# Altium schematic sheet GD32F450IIH6.SchDoc(GD32F450IIH6)
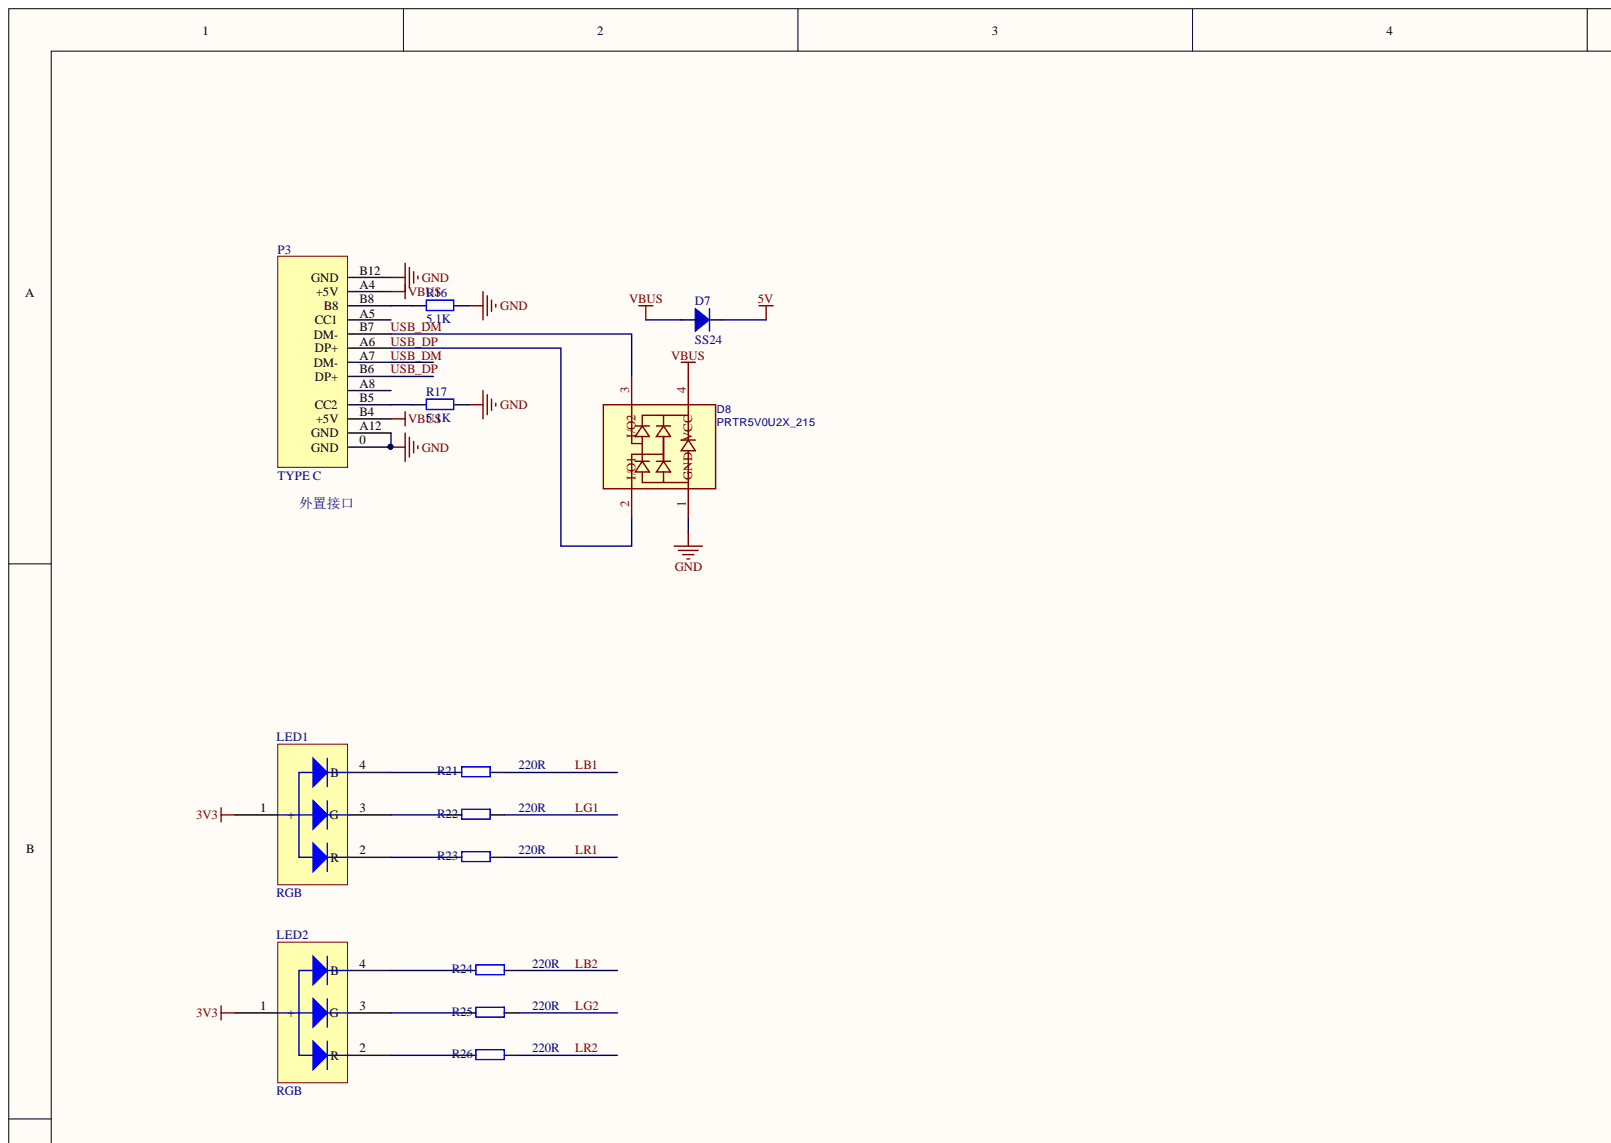
[diagram p0: GD32F450IIH6.SchDoc, top left]
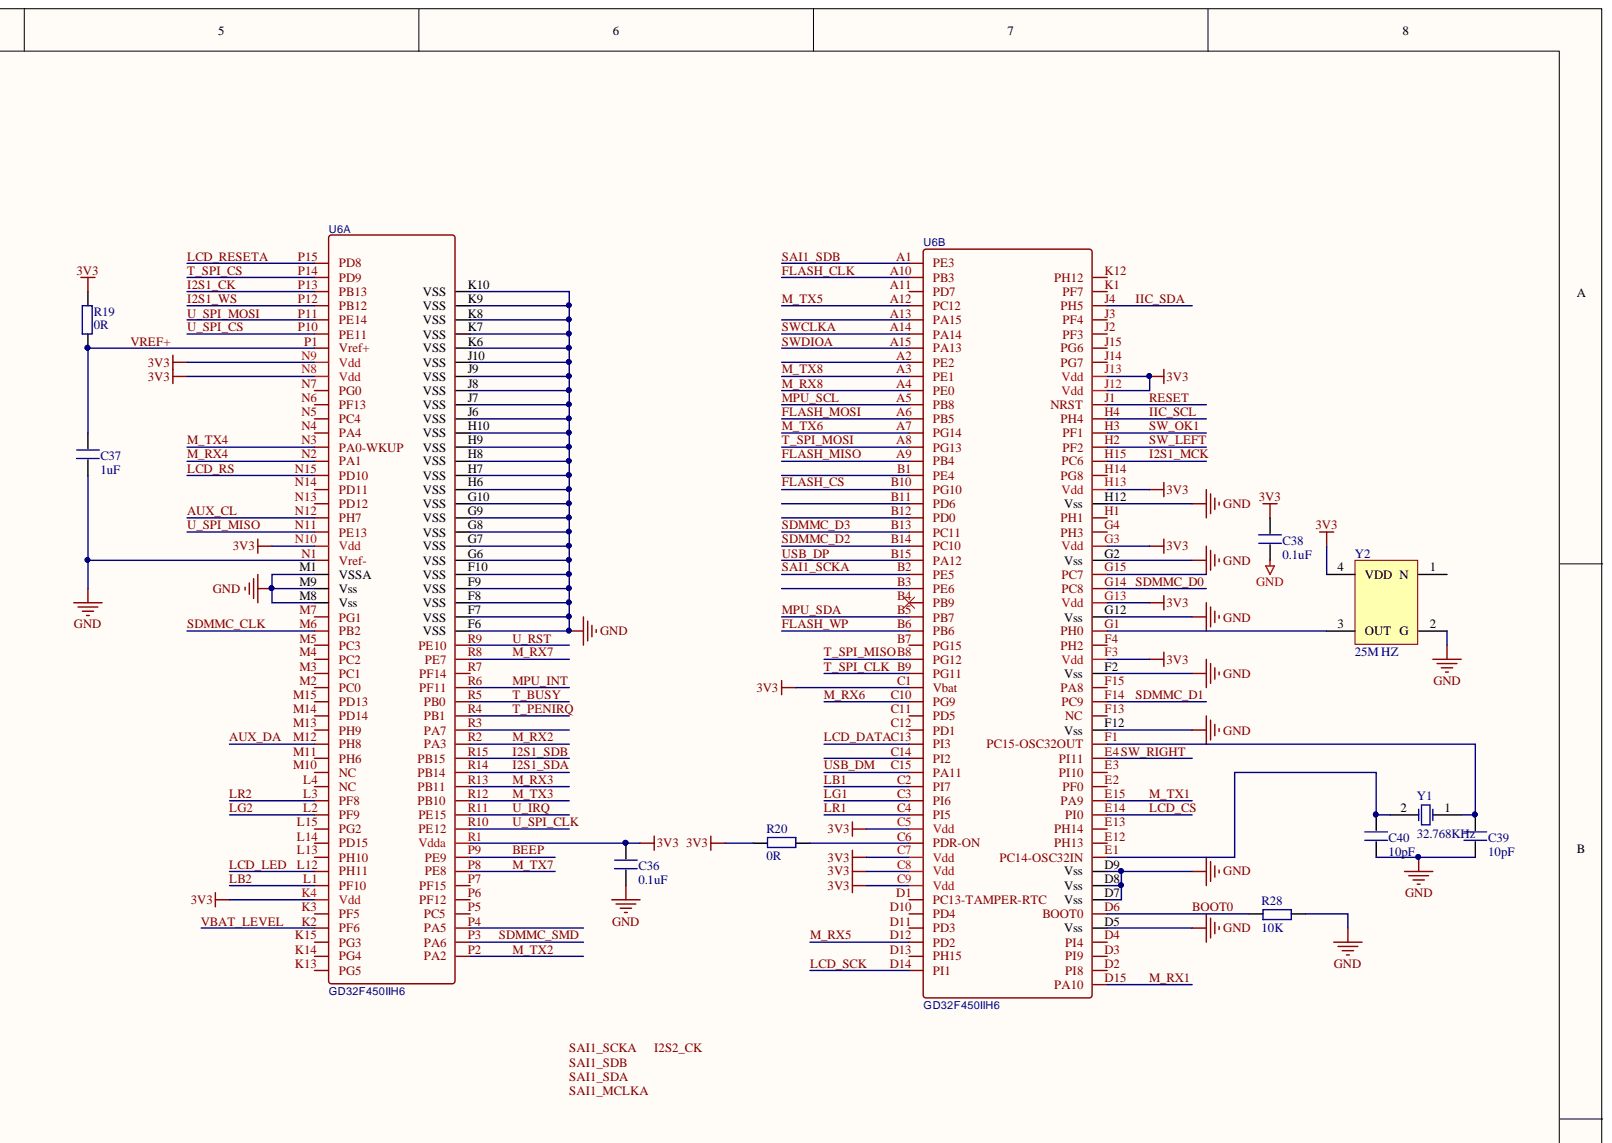
[diagram p1: GD32F450IIH6.SchDoc, top right]
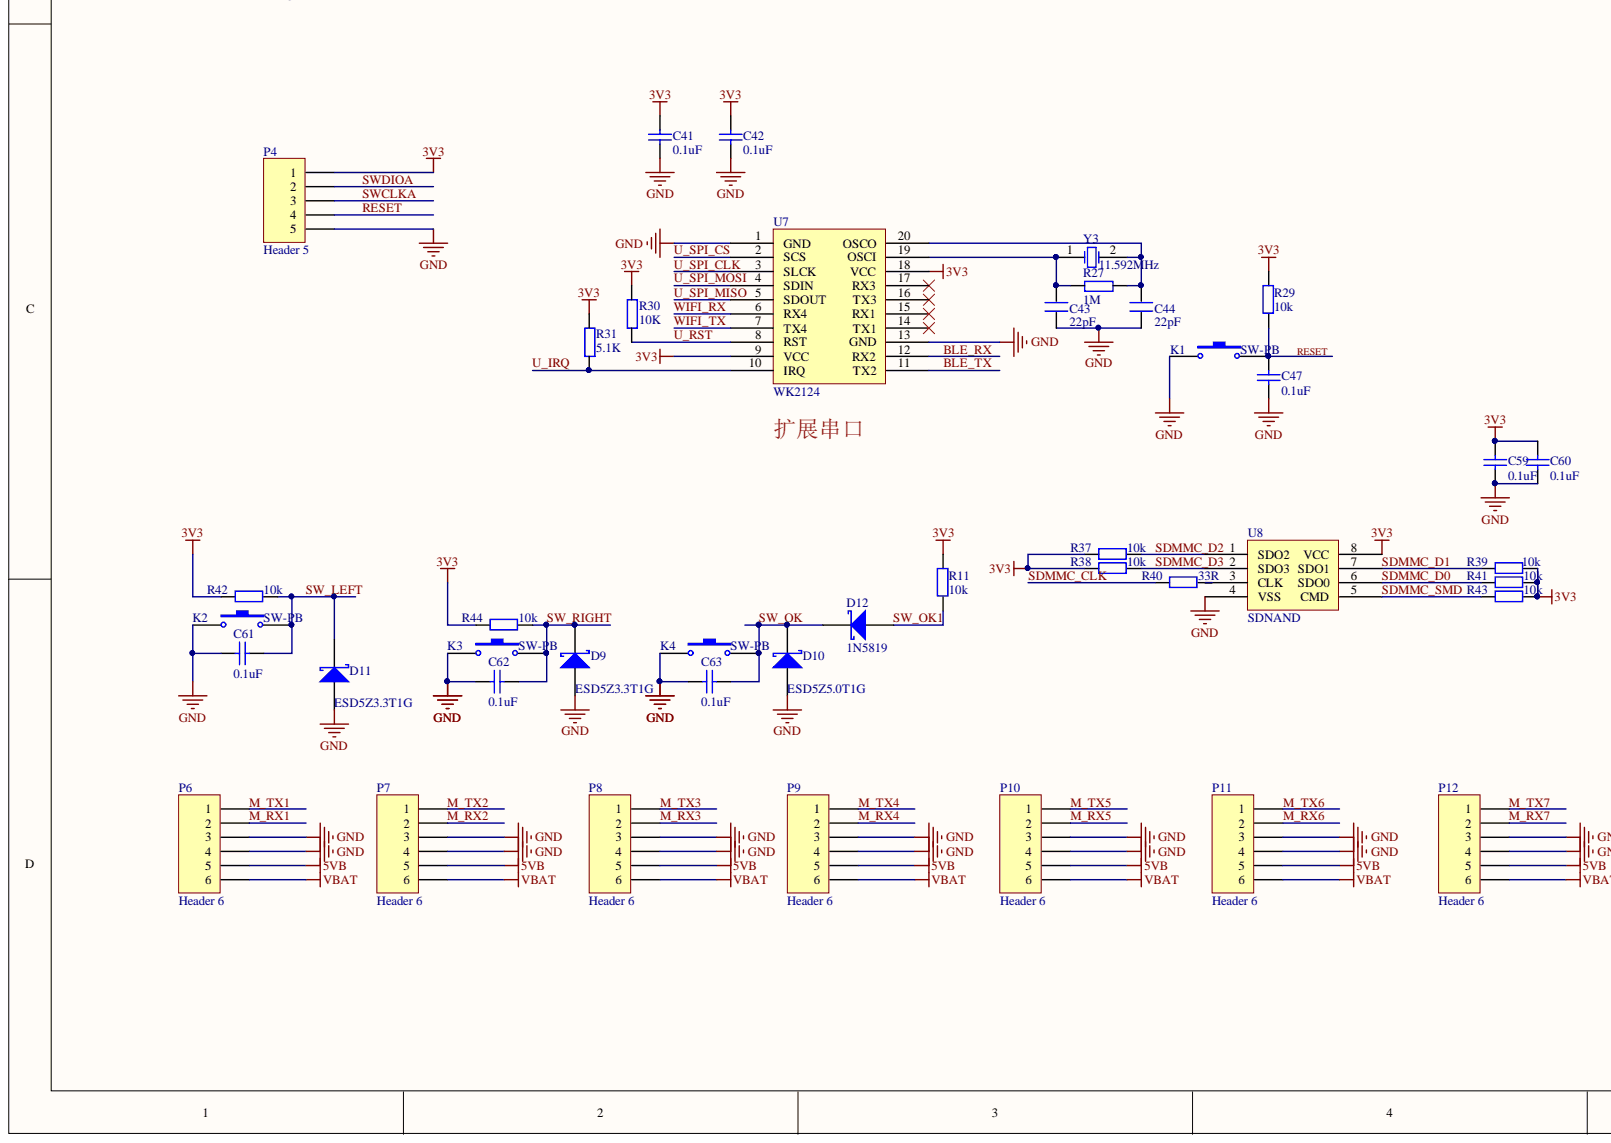
[diagram p2: GD32F450IIH6.SchDoc, bottom left]
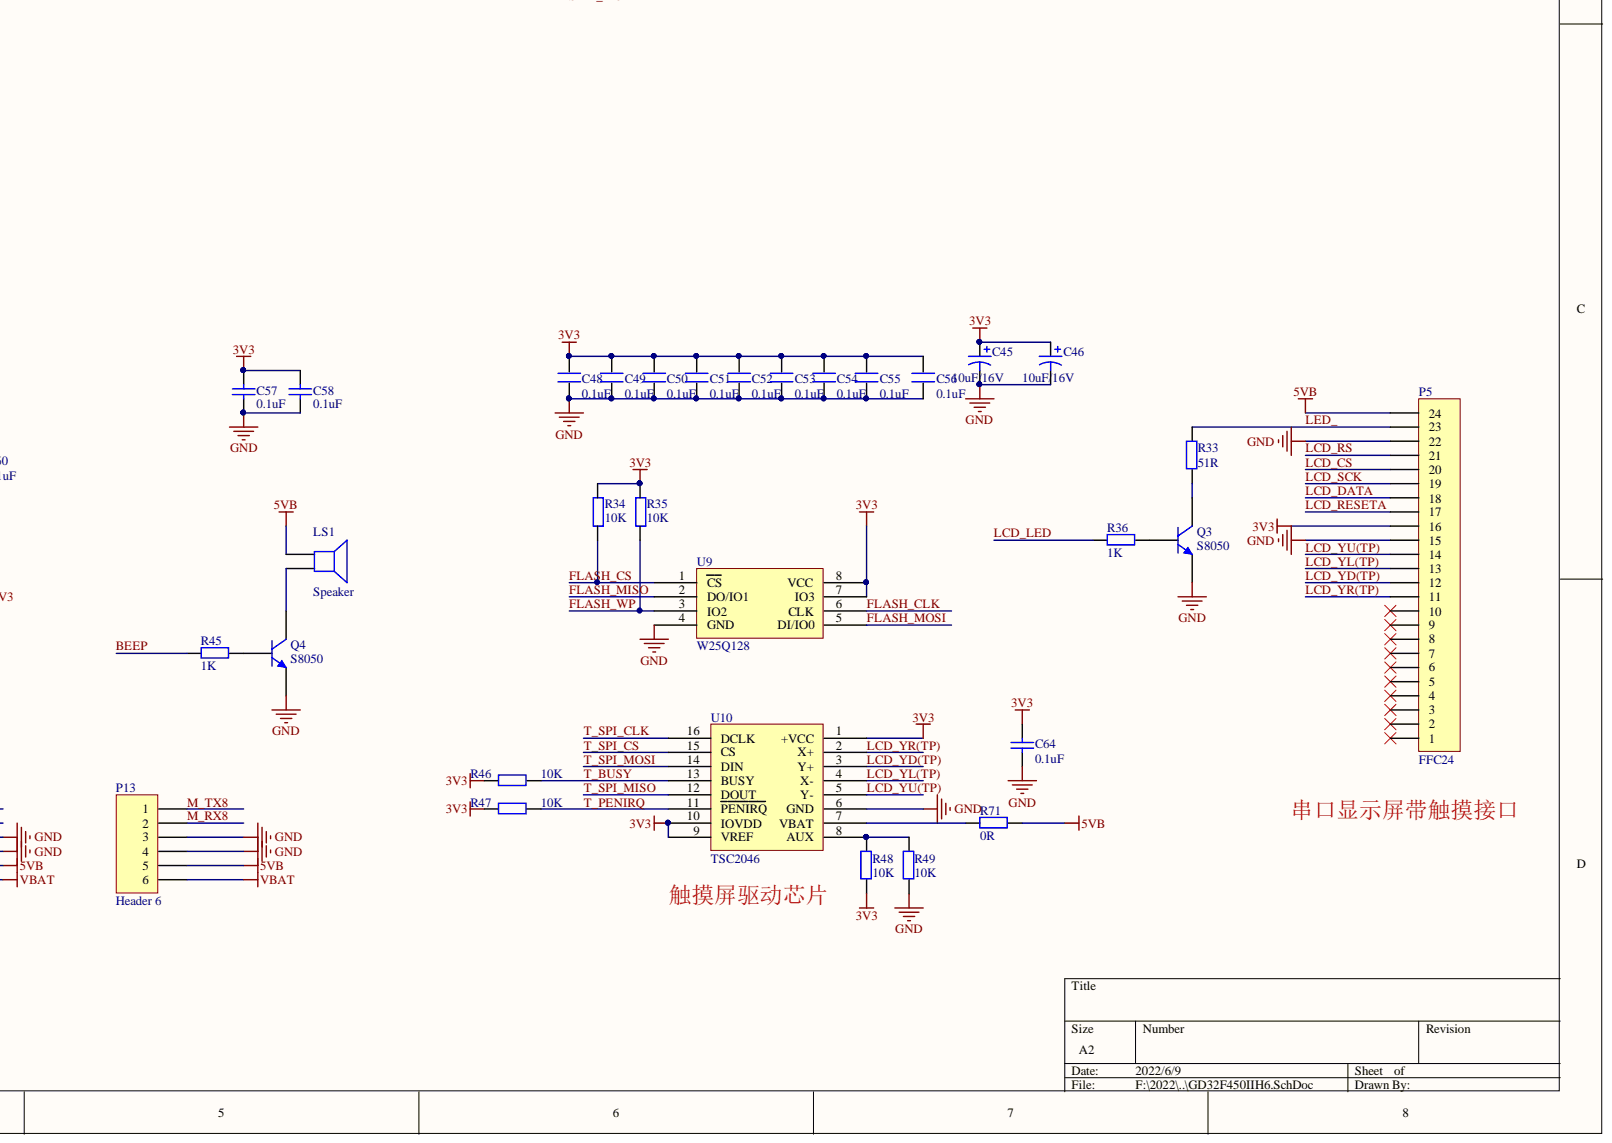
[diagram p3: GD32F450IIH6.SchDoc, bottom right]

## Parts
C36  0.1uF  [C0603]
C37  1uF  [C0603]
C38  0.1uF  [C0603]
C39  10pF  [C0603]
C40  10pF  [C0603]
C41  0.1uF  [C0603]
C42  0.1uF  [C0603]
C43  22pF  [C0603]
C44  22pF  [C0603]
C45  10uF/16V  [1206C+]
C46  10uF/16V  [1206C+]
C47  0.1uF  [C0603]
C48  0.1uF  [C0603]
C49  0.1uF  [C0603]
C50  0.1uF  [C0603]
C51  0.1uF  [C0603]
C52  0.1uF  [C0603]
C53  0.1uF  [C0603]
C54  0.1uF  [C0603]
C55  0.1uF  [C0603]
C56  0.1uF  [C0603]
C57  0.1uF  [C0603]
C58  0.1uF  [C0603]
C59  0.1uF  [C0603]
C60  0.1uF  [C0603]
C61  0.1uF  [C0603]
C62  0.1uF  [C0603]
C63  0.1uF  [C0603]
C64  0.1uF  [C0603]
D7  SS24  [SMA/DO-214AC]
D8  PRTR5V0U2X_215  [PRTR5V0U2X]
D9  ESD5Z3.3T1G  [SOD523]
D10  ESD5Z5.0T1G  [SOD523]
D11  ESD5Z3.3T1G  [SOD523]
D12  1N5819  [SOD323]
K1  SW-PB  [SW_4*4*1.5]
K2  SW-PB  [SW_4*4*1.5]
K3  SW-PB  [SW_4*4*1.5]
K4  SW-PB  [SW_4*4*1.5]
LED1  RGB  [LED_0603_RGB_Y]
LED2  RGB  [LED_0603_RGB_Y]
LS1  Speaker  [BUZ_9*5.5_5]
P3  TYPE C  [USB-TPC-WS]
P4  Header 5  [HDR1X5]
P5  FFC24  [FFC_0.5_24]
P6  Header 6  [WSK-CNTB]
P7  Header 6  [WSK-CNTB]
P8  Header 6  [WSK-CNTB]
P9  Header 6  [WSK-CNTB]
P10  Header 6  [WSK-CNTB]
P11  Header 6  [WSK-CNTB]
P12  Header 6  [WSK-CNTB]
P13  Header 6  [WSK-CNTB]
Q3  S8050  [SOT23]
Q4  S8050  [SOT23]
R11  10k  [R0603]
R16  5.1K  [R0603]
R17  5.1K  [R0603]
R19  0R  [R0603]
R20  0R  [R0603]
R21  220R  [R0603]
R22  220R  [R0603]
R23  220R  [R0603]
R24  220R  [R0603]
R25  220R  [R0603]
R26  220R  [R0603]
R27  1M  [R0603]
R28  10K  [R0603]
R29  10k  [R0603]
R30  10K  [R0603]
R31  5.1K  [R0603]
R33  51R  [R0603]
R34  10K  [R0603]
R35  10K  [R0603]
R36  1K  [R0603]
R37  10k  [R0603]
R38  10k  [R0603]
R39  10k  [R0603]
R40  33R  [R0603]
R41  10k  [R0603]
R42  10k  [R0603]
R43  10k  [R0603]
R44  10k  [R0603]
R45  1K  [R0603]
R46  10K  [R0603]
R47  10K  [R0603]
R48  10K  [R0603]
R49  10K  [R0603]
R71  0R  [R0603]
U6  GD32F450IIH6  [UFBGA-201_L10.0-W10.0-P0.65-TL_STM32H743IIK6]  (parts A,B)
U7  WK2124  [SSOP-20_L7.5-W5.2]
U8  SDNAND  [LGA-8_L6.0-W8.0]
U9  W25Q128  [SOP8_W]
U10  TSC2046  [SSOP16]
Y1  32.768KHz  [X_2012]
Y2  25M HZ  [X_SMD_5*3.2]
Y3  11.592MHz  [X_5032]

## Nets
3V3: C36.2 C38.2 C41.2 C42.2 C45.1 C46.1 C48.2 C49.2 C50.2 C51.2 C52.2 C53.2 C54.2 C55.2 C56.2 C57.2 C58.2 C59.2 C60.2 C64.2 LED1.1(+) LED2.1(+) P4.1 P5.16 R11.2 R19.2 R20.2 R29.1 R30.2 R31.2 R34.2 R35.2 R37.1 R38.1 R39.2 R41.2 R42.2 R43.2 R44.2 R46.2 R47.2 R48.2 U6.C1(Vbat) U6.C5(Vdd) U6.C7(Vdd) U6.C8(Vdd) U6.C9(Vdd) U6.F3(Vdd) U6.G3(Vdd) U6.G13(Vdd) U6.H13(Vdd) U6.J12(Vdd) U6.J13(Vdd) U6.K4(Vdd) U6.N8(Vdd) U6.N9(Vdd) U6.N10(Vdd) U6.R1(Vdda) U7.9(VCC) U7.18(VCC) U8.8(VCC) U9.7(IO3) U9.8(VCC) U10.1(+VCC) U10.9(VREF) U10.10(IOVDD) Y2.4(VDD)
5V: D7.2
5VB: LS1.1 P5.24 P6.5 P7.5 P8.5 P9.5 P10.5 P11.5 P12.5 P13.5 R71.2
GND: C36.1 C37.1 C38.1 C39.1 C40.1 C41.1 C42.1 C43.1 C44.1 C45.2 C46.2 C47.1 C48.1 C49.1 C50.1 C51.1 C52.1 C53.1 C54.1 C55.1 C56.1 C57.1 C58.1 C59.1 C60.1 C61.1 C62.1 C63.1 C64.1 D8.1(GND) D9.1 D10.1 D11.1 K1.1 K2.1 K3.1 K4.1 P3.0(GND) P3.A12(GND) P3.B12(GND) P4.5 P5.15 P5.22 P6.3 P6.4 P7.3 P7.4 P8.3 P8.4 P9.3 P9.4 P10.3 P10.4 P11.3 P11.4 P12.3 P12.4 P13.3 P13.4 Q3.2 Q4.2 R16.1 R17.1 R28.2 R49.2 U6.D5(Vss) U6.D7(Vss) U6.D8(Vss) U6.D9(Vss) U6.F2(Vss) U6.F6(VSS) U6.F7(VSS) U6.F8(VSS) U6.F9(VSS) U6.F10(VSS) U6.F12(Vss) U6.G2(Vss) U6.G6(VSS) U6.G7(VSS) U6.G8(VSS) U6.G9(VSS) U6.G10(VSS) U6.G12(Vss) U6.H6(VSS) U6.H7(VSS) U6.H8(VSS) U6.H9(VSS) U6.H10(VSS) U6.H12(Vss) U6.J6(VSS) U6.J7(VSS) U6.J8(VSS) U6.J9(VSS) U6.J10(VSS) U6.K6(VSS) U6.K7(VSS) U6.K8(VSS) U6.K9(VSS) U6.K10(VSS) U6.M1(VSSA) U6.M8(Vss) U6.M9(Vss) U6.N1(Vref-) U7.1(GND) U7.13(GND) U8.4(VSS) U9.4(GND) U10.6(GND) Y2.2(G)
VBAT: P6.6 P7.6 P8.6 P9.6 P10.6 P11.6 P12.6 P13.6
VBUS: D7.1 D8.4(VCC) P3.A4(+5V) P3.B4(+5V)
AUX_CL: U6.N12(PH7)
AUX_DA: U6.M12(PH8)
BEEP: R45.1 U6.P9(PE9)
BLE_RX: U7.12(RX2)
BLE_TX: U7.11(TX2)
BOOT0: R28.1 U6.D6(BOOT0)
FLASH_CLK: U6.A10(PB3) U9.6(CLK)
FLASH_CS: R34.1 U6.B10(PG10) U9.1(CS)
FLASH_MISO: U6.A9(PB4) U9.2(DO/IO1)
FLASH_MOSI: U6.A6(PB5) U9.5(DI/IO0)
FLASH_WP: R35.1 U6.B6(PB6) U9.3(IO2)
I2S1_CK: U6.P13(PB13)
I2S1_MCK: U6.H15(PC6)
I2S1_SDA: U6.R14(PB14)
I2S1_SDB: U6.R15(PB15)
I2S1_WS: U6.P12(PB12)
IIC_SCL: U6.H4(PH4)
IIC_SDA: U6.J4(PH5)
LB1: R21.1 U6.C2(PI7)
LB2: R24.1 U6.L1(PF10)
LCD_CS: P5.20 U6.E14(PI0)
LCD_DATA: P5.18 U6.C13(PI3)
LCD_LED: R36.1 U6.L12(PH11)
LCD_RESETA: P5.17 U6.P15(PD8)
LCD_RS: P5.21 U6.N15(PD10)
LCD_SCK: P5.19 U6.D14(PI1)
LCD_YD(TP): P5.12 U10.3(Y+)
LCD_YL(TP): P5.13 U10.4(X-)
LCD_YR(TP): P5.11 U10.2(X+)
LCD_YU(TP): P5.14 U10.5(Y-)
LED_: P5.23 R33.1
LG1: R22.1 U6.C3(PI6)
LG2: R25.1 U6.L2(PF9)
LR1: R23.1 U6.C4(PI5)
LR2: R26.1 U6.L3(PF8)
M_RX1: P6.2 U6.D15(PA10)
M_RX2: P7.2 U6.R2(PA3)
M_RX3: P8.2 U6.R13(PB11)
M_RX4: P9.2 U6.N2(PA1)
M_RX5: P10.2 U6.D12(PD2)
M_RX6: P11.2 U6.C10(PG9)
M_RX7: P12.2 U6.R8(PE7)
M_RX8: P13.2 U6.A4(PE0)
M_TX1: P6.1 U6.E15(PA9)
M_TX2: P7.1 U6.P2(PA2)
M_TX3: P8.1 U6.R12(PB10)
M_TX4: P9.1 U6.N3(PA0-WKUP)
M_TX5: P10.1 U6.A12(PC12)
M_TX6: P11.1 U6.A7(PG14)
M_TX7: P12.1 U6.P8(PE8)
M_TX8: P13.1 U6.A3(PE1)
MPU_INT: U6.R6(PF11)
MPU_SCL: U6.A5(PB8)
MPU_SDA: U6.B5(PB7)
RESET: C47.2 K1.2 P4.4 R29.2 U6.J1(NRST)
SAI1_SCKA: U6.B2(PE5)
SAI1_SDB: U6.A1(PE3)
SDMMC_CLK: R40.1 U6.M6(PB2)
SDMMC_D0: R41.1 U6.G14(PC8) U8.6(SDO0)
SDMMC_D1: R39.1 U6.F14(PC9) U8.7(SDO1)
SDMMC_D2: R37.2 U6.B14(PC10) U8.1(SDO2)
SDMMC_D3: R38.2 U6.B13(PC11) U8.2(SDO3)
SDMMC_SMD: R43.1 U6.P3(PA6) U8.5(CMD)
SW_LEFT: C61.2 D11.2 K2.2 R42.1 U6.H2(PF2)
SW_OK: C63.2 D10.2 D12.2 K4.2
SW_OK1: D12.1 R11.1 U6.H3(PF1)
SW_RIGHT: C62.2 D9.2 K3.2 R44.1 U6.E4(PI11)
SWCLKA: P4.3 U6.A14(PA14)
SWDIOA: P4.2 U6.A15(PA13)
T_BUSY: R46.1 U6.R5(PB0) U10.13(BUSY)
T_PENIRQ: R47.1 U6.R4(PB1) U10.11(PENIRQ)
T_SPI_CLK: U6.B9(PG11) U10.16(DCLK)
T_SPI_CS: U6.P14(PD9) U10.15(CS)
T_SPI_MISO: U6.B8(PG12) U10.12(DOUT)
T_SPI_MOSI: U6.A8(PG13) U10.14(DIN)
U_IRQ: R31.1 U6.R11(PE15) U7.10(IRQ)
U_RST: R30.1 U6.R9(PE10) U7.8(RST)
U_SPI_CLK: U6.R10(PE12) U7.3(SLCK)
U_SPI_CS: U6.P10(PE11) U7.2(SCS)
U_SPI_MISO: U6.N11(PE13) U7.5(SDOUT)
U_SPI_MOSI: U6.P11(PE14) U7.4(SDIN)
USB_DM: D8.3(I/O2) P3.A7(DM-) P3.B7(DM-) U6.C15(PA11)
USB_DP: D8.2(I/O1) P3.A6(DP+) P3.B6(DP+) U6.B15(PA12)
VBAT_LEVEL: U6.K2(PF6)
VREF+: C37.2 R19.1 U6.P1(Vref+)
WIFI_RX: U7.6(RX4)
WIFI_TX: U7.7(TX4)
NetC39_2: C39.2 U6.F1(PC15-OSC32OUT) Y1.1
NetC40_2: C40.2 U6.E1(PC14-OSC32IN) Y1.2
NetC43_2: C43.2 R27.1 U7.19(OSCI) Y3.1
NetC44_2: C44.2 R27.2 U7.20(OSCO) Y3.2
NetLED1_2: LED1.2(R) R23.2
NetLED1_3: LED1.3(G) R22.2
NetLED1_4: LED1.4(B) R21.2
NetLED2_2: LED2.2(R) R26.2
NetLED2_3: LED2.3(G) R25.2
NetLED2_4: LED2.4(B) R24.2
NetLS1_2: LS1.2 Q4.3
NetP3_B5: P3.B5(CC2) R17.2
NetP3_B8: P3.B8 R16.2
NetQ3_1: Q3.1 R36.2
NetQ3_3: Q3.3 R33.2
NetQ4_1: Q4.1 R45.2
NetR20_1: R20.1 U6.C6(PDR-ON)
NetR40_2: R40.2 U8.3(CLK)
NetR48_1: R48.1 R49.1 U10.8(AUX)
NetR71_1: R71.1 U10.7(VBAT)
NetU6_G1: U6.G1(PH0) Y2.3(OUT)
I2S2_CK:
SAI1_MCLKA:
SAI1_SDA:

Not connected: P3 A5(CC1) A8; P5 1 2 3 4 5 6 7 8 9 10; U6 A2(PE2) A11(PD7) A13(PA15) B1(PE4) B3(PE6) B4(PB9) B7(PG15) B11(PD6) B12(PD0) C11(PD5) C12(PD1) C14(PI2) D1(PC13-TAMPER-RTC) D2(PI8) D3(PI9) D4(PI4) D10(PD4) D11(PD3) D13(PH15) E2(PF0) E3(PI10) E12(PH13) E13(PH14) F4(PH2) F13(NC) F15(PA8) G4(PH3) G15(PC7) H1(PH1) H14(PG8) J2(PF3) J3(PF4) J14(PG7) J15(PG6) K1(PF7) K3(PF5) K12(PH12) K13(PG5) K14(PG4) K15(PG3) L4(NC) L13(PH10) L14(PD15) L15(PG2) M2(PC0) M3(PC1) M4(PC2) M5(PC3) M7(PG1) M10(NC) M11(PH6) M13(PH9) M14(PD14) M15(PD13) N4(PA4) N5(PC4) N6(PF13) N7(PG0) N13(PD12) N14(PD11) P4(PA5) P5(PC5) P6(PF12) P7(PF15) R3(PA7) R7(PF14); U7 14(TX1) 15(RX1) 16(TX3) 17(RX3); Y2 1(N)

## Library data of the parts
C36-C40 C43 C44 C48-C56 = C: Component Kind: Standard; Library Name: Explorer STM32F407封装库.IntLib
C41 C42 C47 C57-C64 = Cap: Capacitor; Component Kind: Standard; LatestRevisionDate: 17-Jul-2002; LatestRevisionNote: Re-released for DXP Platform.; Library Name: Miscellaneous Devices.IntLib; PackageDescription: Radial Cap, Thru-Hole; 2 Leads; 0.3 in Pin Spacing; PackageReference: 0603C; Published: 8-Jun-2000; Signal Integrity: Cap; Simulation: CAP; Value: 0.1uF; Publisher: Altium Limited
C45 C46 = Cap Pol1: Polarized Capacitor (Radial); Component Kind: Standard; LatestRevisionDate: 17-Jul-2002; LatestRevisionNote: Re-released for DXP Platform.; Library Name: Miscellaneous Devices.IntLib; PackageDescription: Polarized Capacitor (Radial); 2 Leads; PackageReference: RB7.6-15; Published: 8-Jun-2000; Signal Integrity: Cap Pol; Simulation: CAP; Value: 10uF/16V; Publisher: Altium Limited
D7 = D1: Component Kind: Standard; Library Name: 无限续航4口机柜原理图V6.0(2).SCHLIB
D8 = PRTR5V0U2X_215: BOM_Manufacturer: Nexperia USA Inc.; BOM_Manufacturer Part: PRTR5V0U2X,215; Contributor: KiCAD; BOM_Supplier: DigiKey; BOM_Supplier Part: 1727-3884-1-ND; Component Kind: Standard; license: https://www.gnu.org/licenses/lgpl-2.1.html; spicePre: D; spiceSymbolName: PRTR5V0U2X_215
D9-D12 = D Schottky: Schottky Diode; Code_JEDEC: DO-214-AA; Component Kind: Standard; LatestRevisionDate: 17-Jul-2002; LatestRevisionNote: Re-released for DXP Platform.; Library Name: Miscellaneous Devices.IntLib; PackageDescription: DO-214-AA/SMB; 2 C-Bend Leads; Body 5.3 x 3.6 mm, inc. leads (LxW); PackageReference: SMB; Published: 8-Jun-2000; Publisher: Altium Limited
  D12: Signal Integrity: D Schottky; Simulation: SKYDIODE
K1-K4 = SW-PB: Switch; Component Kind: Standard; LatestRevisionDate: 17-Jul-2002; LatestRevisionNote: Re-released for DXP Platform.; Library Name: Miscellaneous Devices.IntLib; PackageDescription: Switch; 2 Leads; PackageReference: SPST-2; Published: 24-Mar-1999; Publisher: Altium Limited
LED1 LED2 = LED_3C: Component Kind: Standard; Library Name: 2 按键.SCHLIB
LS1 = Speaker: Loudspeaker; Component Kind: Standard; LatestRevisionDate: 17-Jul-2002; LatestRevisionNote: Re-released for DXP Platform.; Library Name: Miscellaneous Devices.IntLib; PackageReference: PIN2; Published: 24-Mar-1999; Publisher: Altium Limited
P4 = Header 5: Header, 5-Pin; Component Kind: Standard; LatestRevisionDate: 17-Jul-2002; LatestRevisionNote: Re-released for DXP Platform.; Library Name: Miscellaneous Connectors.IntLib; Signal Integrity: Connector; Publisher: Altium Limited
P5 = Header 24: Header, 24-Pin; Component Kind: Standard; LatestRevisionDate: 17-Jul-2002; LatestRevisionNote: Re-released for DXP Platform.; Library Name: Miscellaneous Connectors.IntLib; Signal Integrity: Connector; Publisher: Altium Limited
P6-P13 = Header 6: Header, 6-Pin; Component Kind: Standard; LatestRevisionDate: 17-Jul-2002; LatestRevisionNote: Re-released for DXP Platform.; Library Name: Miscellaneous Connectors.IntLib; Signal Integrity: Connector; Publisher: Altium Limited
Q3 Q4 = NPN: NPN Bipolar Transistor; Code_JEDEC: TO-226-AA; Component Kind: Standard; LatestRevisionDate: 17-Jul-2002; LatestRevisionNote: Re-released for DXP Platform.; Library Name: Miscellaneous Devices.IntLib; PackageDescription: TO, Flat Index; 3 In-Line, Axial Leads; Body Dia. 4.8mm; Leads 0.55 x 0.51 mm (max); PackageReference: TO-226-AA; Published: 8-Jun-2000; Signal Integrity: NPN; Simulation: NPN; Publisher: Altium Limited
R11 R29 R36-R45 = Res2: Resistor; Component Kind: Standard; LatestRevisionDate: 17-Jul-2002; LatestRevisionNote: Re-released for DXP Platform.; Library Name: Miscellaneous Devices.IntLib; PackageDescription: Axial Device, Thru-Hole; 2 Leads; 0.4 in Pin Spacing; Published: 8-Jun-2000; Signal Integrity: Res; Simulation: RESISTOR; Publisher: Altium Limited
  R11 R29 R37-R39 R41-R44: PackageReference: 0603R; Value: 10k
  R36 R45: PackageReference: AXIAL-0.4; Value: 1K
  R40: PackageReference: 0603R; Value: 33R
R16 R17 R33 R46-R49 R71 = Res2: Resistor; Value: 1K; Component Kind: Standard; LatestRevisionDate: 17-Jul-2002; LatestRevisionNote: Re-released for DXP Platform.; Library Name: Miscellaneous Devices.IntLib; PackageDescription: Axial Device, Thru-Hole; 2 Leads; 0.4 in Pin Spacing; PackageReference: AXIAL-0.4; Published: 8-Jun-2000; Signal Integrity: Res; Simulation: RESISTOR; Publisher: Altium Limited
R19-R28 R30 R31 R34 R35 = R: Component Kind: Standard; Library Name: Explorer STM32F407封装库.IntLib
U6 = GD32F450IIH6: BOM_Manufacturer: GigaDevice Semicon Beijing; subpart_no: 2; Contributor: LCSC; BOM_JLCPCB Part Class: Extended Part; BOM_Manufacturer Part: GD32F450IIH6; BOM_Supplier: LCSC; BOM_Supplier Part: C179655; Component Kind: Standard; Library Name: STM32F429_F746.SCHLIB; spicePre: U; spiceSymbolName: GD32F450IIH6
U9 = W25Q32JV: Component Kind: Standard; Library Name: Schlib1.SchLib
Y1 = XTAL_1: Crystal Oscillator; Component Kind: Standard; LatestRevisionDate: 17-Jul-2002; LatestRevisionNote: Re-released for DXP Platform.; Library Name: Explorer STM32F407封装库.IntLib; Published: 8-Jun-2000; Simulation: XTAL; Publisher: Altium Limited
Y2 = 4T: Component Kind: Standard; Library Name: 芯片类.SchLib
Y3 = XTAL: Crystal Oscillator; Component Kind: Standard; LatestRevisionDate: 17-Jul-2002; LatestRevisionNote: Re-released for DXP Platform.; Library Name: Explorer STM32F407封装库.IntLib; Published: 8-Jun-2000; Simulation: XTAL; Publisher: Altium Limited
P3 = TYPE C: Component Kind: Standard; Library Name: 芯片类.SchLib
U7 = WK2124: Component Kind: Standard; Library Name: 芯片类.SchLib
U8 = SDNAND: Component Kind: Standard; Library Name: 芯片类.SchLib
U10 = TSC2046: Component Kind: Standard; Library Name: 芯片类.SchLib
